# Altium schematic sheet Sheet1.SchDoc(Sheet1)
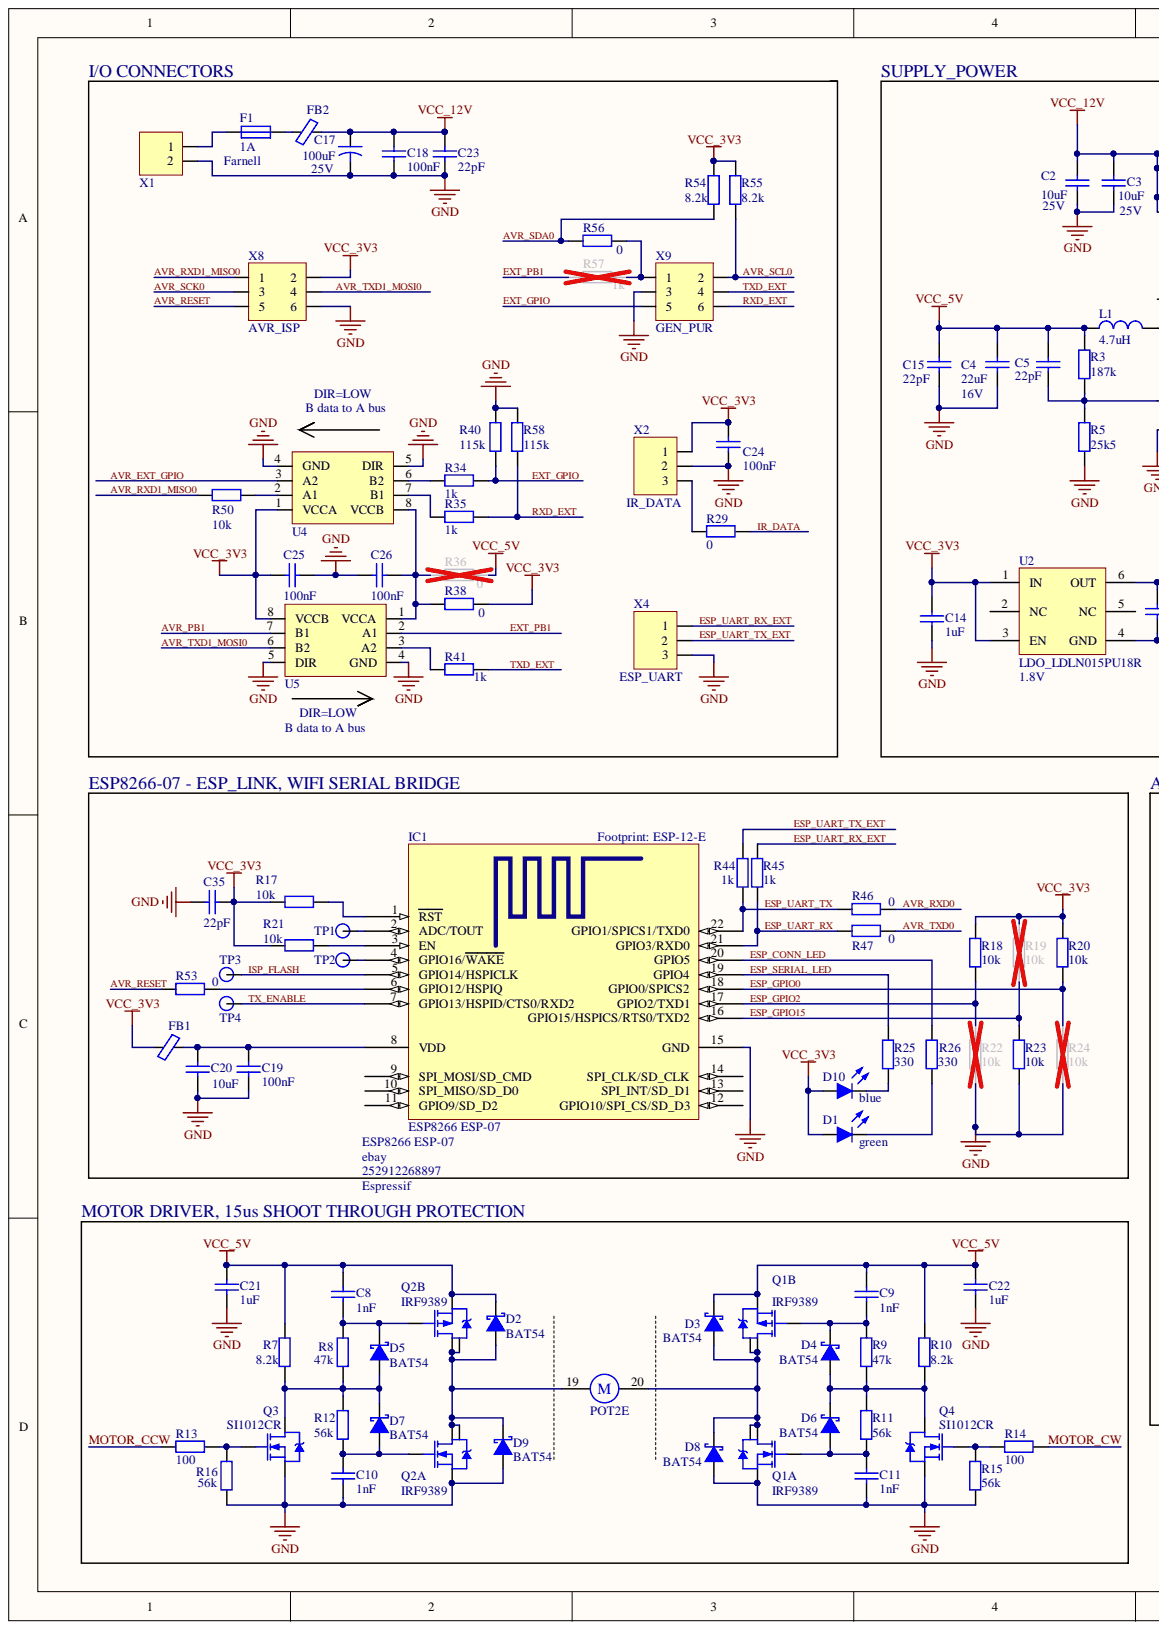
[diagram p0: Sheet1.SchDoc, left]
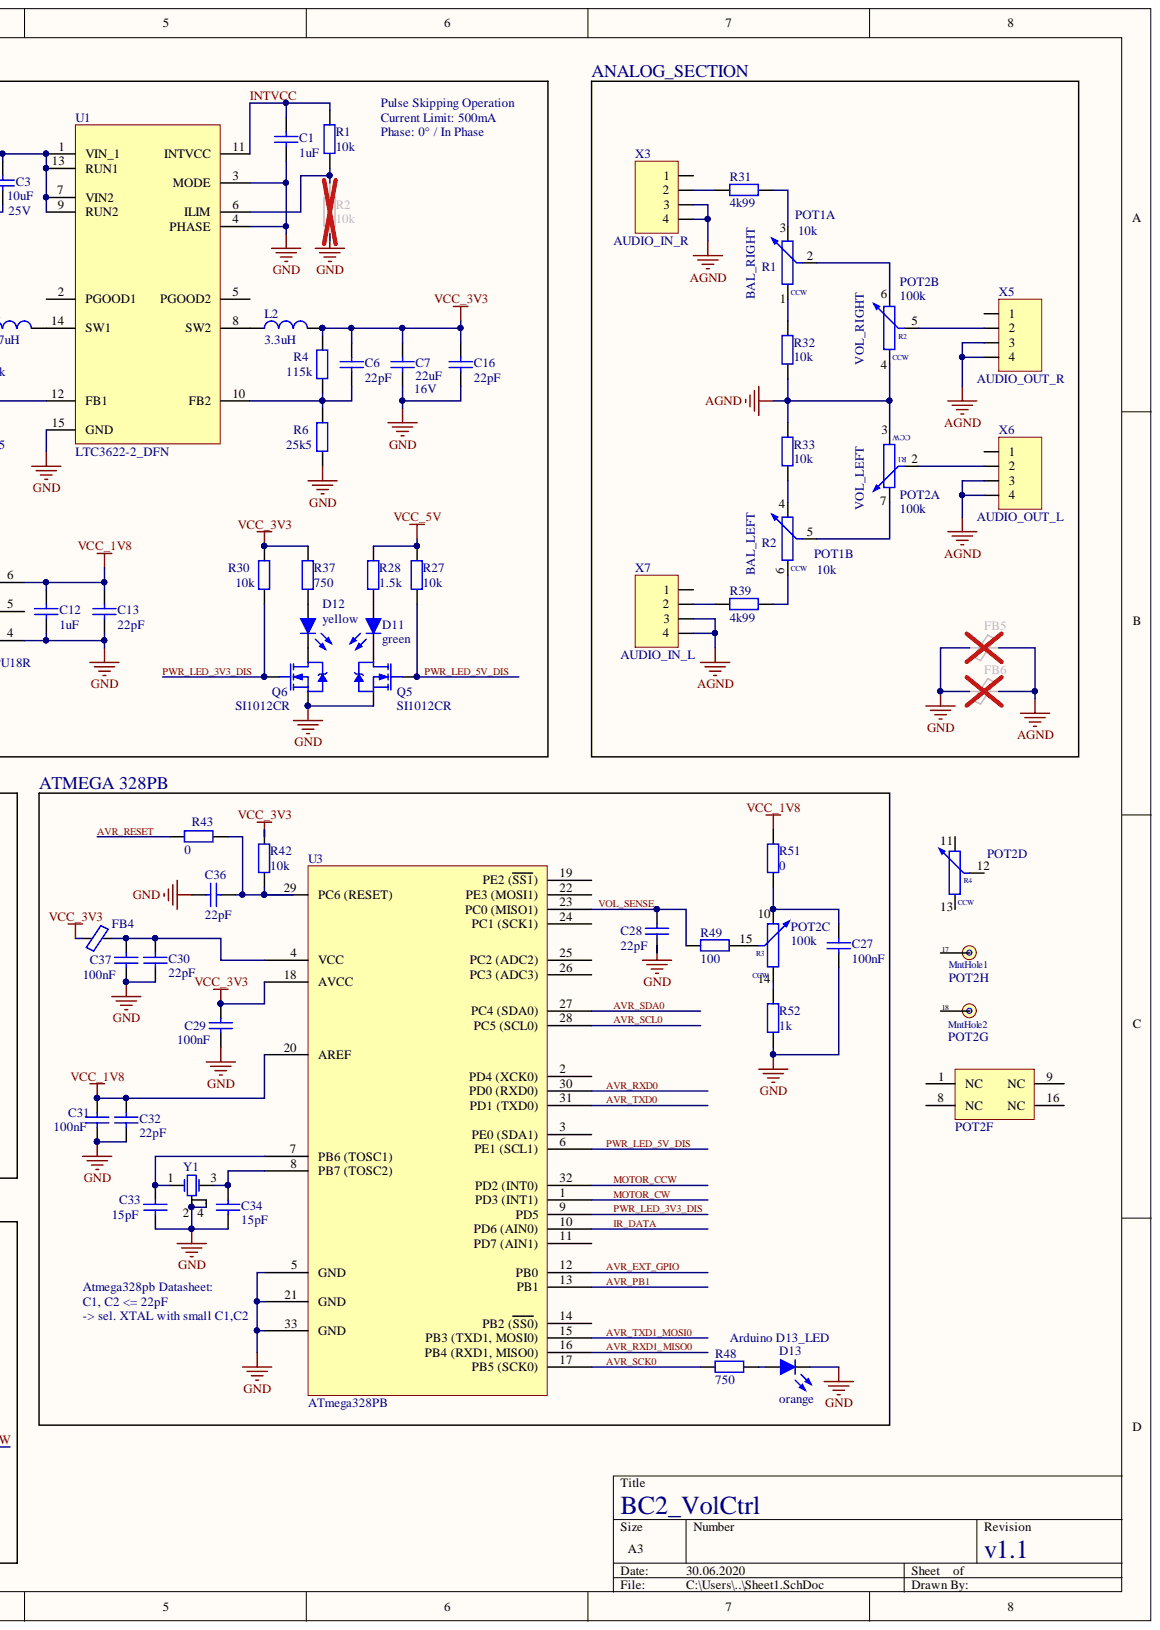
[diagram p1: Sheet1.SchDoc, right]

## Parts
C1  value 1uF  [CAPC1608N]
C2  value 10uF  Voltage: 25V  [CAPC3216N]
C3  value 10uF  Voltage: 25V  [CAPC3216N]
C4  value 22uF  Voltage: 16V  [CAPC2012N]
C5  value 22pF  [CAPC1608N]
C6  value 22pF  [CAPC1608N]
C7  value 22uF  Voltage: 16V  [CAPC2012N]
C8  value 1nF  [CAPC1608N]
C9  value 1nF  [CAPC1608N]
C10  value 1nF  [CAPC1608N]
C11  value 1nF  [CAPC1608N]
C12  value 1uF  [CAPC1608N]
C13  value 22pF  [CAPC1608N]
C14  value 1uF  [CAPC1608N]
C15  value 22pF  [CAPC1608N]
C16  value 22pF  [CAPC1608N]
C17  value 100uF  Voltage: 25V  [CRAD_6.3mm]  (hidden comment: FK Series)
C18  value 100nF  [CAPC1608N]
C19  value 100nF  [CAPC1608N]
C20  value 10uF  [CAPC3216N]  (hidden comment: C1206_10uF)
C21  value 1uF  [CAPC1608N]
C22  value 1uF  [CAPC1608N]
C23  value 22pF  [CAPC1608N]
C24  value 100nF  [CAPC1608N]
C25  value 100nF  [CAPC1608N]
C26  value 100nF  [CAPC1608N]
C27  value 100nF  [CAPC1608N]
C28  value 22pF  [CAPC1608N]
C29  value 100nF  [CAPC1608N]
C30  value 22pF  [CAPC1608N]
C31  value 100nF  [CAPC1608N]
C32  value 22pF  [CAPC1608N]
C33  value 15pF  [CAPC1608N]
C34  value 15pF  [CAPC1608N]
C35  value 22pF  [CAPC1608N]
C36  value 22pF  [CAPC1608N]
C37  value 100nF  [CAPC1608N]
D1  Color: green  [LED_0603]
D2  BAT54  [SOD323]
D3  BAT54  [SOD323]
D4  BAT54  [SOD323]
D5  BAT54  [SOD323]
D6  BAT54  [SOD323]
D7  BAT54  [SOD323]
D8  BAT54  [SOD323]
D9  BAT54  [SOD323]
D10  Color: blue  [LED_0603]
D11  Color: green  [LED_0603]
D12  Color: yellow  [LED_0603]
D13  Color: orange  [LED_0603]
F1  Supplier: Farnell  Current: 1A  [RESC1608N]  (hidden comment: Fuse_0603_1A)
FB1  FB_1.5k_1A  [0805_FERRITE]  (value not drawn)
FB2  FB_1.5k_1A  [0805_FERRITE]  (value not drawn)
FB4  FB_1.5k_1A  [0805_FERRITE]  (value not drawn)
FB5  FB_1.5k_1A  [0805_FERRITE]  (value not drawn)
FB6  FB_1.5k_1A  [0805_FERRITE]  (value not drawn)
IC1  ESP8266 ESP-07  Supplier: ebay  [ESP8266 ESP-12-E]
L1  value 4.7uH  [L_XFL4020]  (hidden comment: XFL4020)
L2  value 3.3uH  [L_XFL4020]  (hidden comment: XFL4020)
POT1  value 10k  [RK27112A]  (hidden comment: POT_RK27112A)  (parts A,B)
POT2  value 100k  [RK16814MGA0K]  (parts A,B,C,D,E,F,G,H)
Q1  IRF9389  [SO-8]  (parts A,B)
Q2  IRF9389  [SO-8]  (parts A,B)
Q3  SI1012CR  [SC-75A]
Q4  SI1012CR  [SC-75A]
Q5  SI1012CR  [SC-75A]
Q6  SI1012CR  [SC-75A]
R1  value 10k  [RESC1608N]
R2  value 10k  [RESC1608N]
R3  value 187k  [RESC1608N]
R4  value 115k  [RESC1608N]
R5  value 25k5  [RESC1608N]
R6  value 25k5  [RESC1608N]
R7  value 8.2k  [RESC1608N]
R8  value 47k  [RESC1608N]
R9  value 47k  [RESC1608N]
R10  value 8.2k  [RESC1608N]
R11  value 56k  [RESC1608N]
R12  value 56k  [RESC1608N]
R13  value 100  [RESC1608N]
R14  value 100  [RESC1608N]
R15  value 56k  [RESC1608N]
R16  value 56k  [RESC1608N]
R17  value 10k  [RESC1608N]
R18  value 10k  [RESC1608N]
R19  value 10k  [RESC1608N]
R20  value 10k  [RESC1608N]
R21  value 10k  [RESC1608N]
R22  value 10k  [RESC1608N]
R23  value 10k  [RESC1608N]
R24  value 10k  [RESC1608N]
R25  value 330  [RESC1608N]
R26  value 330  [RESC1608N]
R27  value 10k  [RESC1608N]
R28  value 1.5k  [RESC1608N]  (hidden comment: R0603_1.5k)
R29  value 0  [RESC1608N]
R30  value 10k  [RESC1608N]
R31  value 4k99  [RESC1608N]
R32  value 10k  [RESC1608N]
R33  value 10k  [RESC1608N]
R34  value 1k  [RESC1608N]
R35  value 1k  [RESC1608N]
R36  value 0  [RESC1608N]
R37  value 750  [RESC1608N]
R38  value 0  [RESC1608N]
R39  value 4k99  [RESC1608N]
R40  value 115k  [RESC1608N]
R41  value 1k  [RESC1608N]
R42  value 10k  [RESC1608N]
R43  value 0  [RESC1608N]
R44  value 1k  [RESC1608N]
R45  value 1k  [RESC1608N]
R46  value 0  [RESC1608N]
R47  value 0  [RESC1608N]
R48  value 750  [RESC1608N]
R49  value 100  [RESC1608N]
R50  value 10k  [RESC1608N]
R51  value 0  [RESC1608N]
R52  value 1k  [RESC1608N]  (hidden comment: R0603_1k)
R53  value 0  [RESC1608N]
R54  value 8.2k  [RESC1608N]  (hidden comment: R0603_8.2k)
R55  value 8.2k  [RESC1608N]  (hidden comment: R0603_8.2k)
R56  value 0  [RESC1608N]
R57  value 1k  [RESC1608N]
R58  value 115k  [RESC1608N]
TP1  (no value)  [TP_0.3mm]
TP2  (no value)  [TP_0.3mm]
TP3  (no value)  [TP_0.3mm]
TP4  (no value)  [TP_0.3mm]
U1  LTC3622-2_DFN  [DFN-14]
U2  LDO_LDLN015PU18R  Vout: 1.8V  [DFN6]
U3  ATmega328PB  [ATMEL_32M1-A_L]
U4  (no value)  [SSOP-8_DCT]
U5  (no value)  [SSOP-8_DCT]
X1  Con_2x1_2.54mm  [HDR2x1]  (value not drawn)
X2  IR_DATA  [HDR3x1]
X3  AUDIO_IN_R  [MOLEX_MINI_FIT_4PIN]
X4  ESP_UART  [HDR3x1]
X5  AUDIO_OUT_R  [MOLEX_MINI_FIT_4PIN]
X6  AUDIO_OUT_L  [MOLEX_MINI_FIT_4PIN]
X7  AUDIO_IN_L  [MOLEX_MINI_FIT_4PIN]
X8  AVR_ISP  [HDR2X3_1_15_Drill]
X9  GEN_PUR  [HDR2X3_1_15_Drill]
Y1  XTAL_8MHz  [XTAL_5x3.2mm]  (value not drawn)

## Nets
AGND: FB5.1 FB6.1 POT2.3 POT2.4 R32.1 R33.1 X3.3 X3.4 X5.3 X5.4 X6.3 X6.4 X7.3 X7.4
GND: C1.1 C2.2 C3.1 C4.1 C7.1 C10.1 C11.1 C12.1 C13.1 C14.1 C15.2 C16.1 C17.2 C18.1 C19.1 C20.1 C21.1 C22.1 C23.1 C24.1 C25.2 C26.2 C27.1 C28.1 C29.1 C30.1 C31.1 C32.1 C33.1 C34.1 C35.2 C36.2 C37.1 D8.1 D9.1 D13.2 FB5.2 FB6.2 IC1.15(GND) Q1.1 Q2.1 Q3.2 Q4.2 Q5.2 Q6.2 R2.1 R5.1 R6.1 R15.1 R16.1 R22.1 R23.1 R24.1 R40.1 R52.1 R58.1 U1.3(MODE) U1.4(PHASE) U1.15(GND) U2.4(GND) U3.5(GND) U3.21(GND) U3.33(GND) U4.4(GND) U4.5(DIR) U5.4(GND) U5.5(DIR) X1.2 X2.2 X4.3 X8.6 X9.3 Y1.2 Y1.4
VCC_1V8: C12.2 C13.2 C31.2 C32.2 R51.2 U2.6(OUT) U3.20(AREF)
VCC_3V3: C6.2 C7.2 C14.2 C16.2 C24.2 C25.1 C29.2 C35.1 D1.1 D10.1 FB1.2 FB4.1 L2.2 R4.2 R17.2 R18.2 R19.2 R20.2 R21.2 R30.2 R37.2 R38.2 R42.2 R54.2 R55.1 U2.1(IN) U2.3(EN) U3.18(AVCC) U4.1(VCCA) U5.8(VCCB) X2.1 X8.2
VCC_5V: C4.2 C5.2 C8.2 C9.2 C15.1 C21.2 C22.2 D2.2 D3.2 L1.1 Q1.3 Q2.3 R3.1 R7.1 R10.1 R27.2 R28.2 R36.2
VCC_12V: C2.1 C3.2 C17.1 C18.2 C23.2 FB2.1 U1.1(VIN_1) U1.7(VIN2) U1.9(RUN2) U1.13(RUN1)
AVR_EXT_GPIO: U3.12(PB0) U4.3(A2)
AVR_PB1: U3.13(PB1) U5.7(B1)
AVR_RESET: R43.1 R53.1 X8.5
AVR_RXD0: R46.2 U3.30(PD0_(RXD0))
AVR_RXD1_MISO0: R50.2 U3.16(PB4_(RXD1,_MISO0)) X8.1
AVR_SCK0: R48.1 U3.17(PB5_(SCK0)) X8.3
AVR_SCL0: R55.2 U3.28(PC5_(SCL0)) X9.2
AVR_SDA0: R54.1 R56.1 U3.27(PC4_(SDA0))
AVR_TXD0: R47.2 U3.31(PD1_(TXD0))
AVR_TXD1_MOSI0: U3.15(PB3_(TXD1,_MOSI0)) U5.6(B2) X8.4
ESP_CONN_LED: IC1.20(GPIO5) R26.2
ESP_GPIO0: IC1.18(GPIO0/SPICS2) R20.1 R24.2
ESP_GPIO2: IC1.17(GPIO2/TXD1) R18.1 R22.2
ESP_GPIO15: IC1.16(GPIO15/HSPICS/RTS0/TXD2) R19.1 R23.2
ESP_SERIAL_LED: IC1.19(GPIO4) R25.2
ESP_UART_RX: IC1.21(GPIO3/RXD0) R45.2 R47.1
ESP_UART_RX_EXT: R45.1 X4.1
ESP_UART_TX: IC1.22(GPIO1/SPICS1/TXD0) R44.2 R46.1
ESP_UART_TX_EXT: R44.1 X4.2
EXT_GPIO: R34.2 R40.2 X9.5
EXT_PB1: R57.2 U5.2(A1)
INTVCC: C1.2 R1.2 U1.11(INTVCC)
IR_DATA: R29.2 U3.10(PD6_(AIN0))
ISP_FLASH: IC1.5(GPIO14/HSPICLK) TP3.1
MOTOR_CCW: R13.1 U3.32(PD2_(INT0))
MOTOR_CW: R14.1 U3.1(PD3_(INT1))
PWR_LED_3V3_DIS: Q6.1 R30.1 U3.9(PD5)
PWR_LED_5V_DIS: Q5.1 R27.1 U3.6(PE1_(SCL1))
RXD_EXT: R35.1 R58.2 X9.6
TX_ENABLE: IC1.7(GPIO13/HSPID/CTS0/RXD2) TP4.1
TXD_EXT: R41.1 X9.4
VOL_SENSE: C28.2 R49.2 U3.23(PC0_(MISO1))
NetC5_1: C5.1 R3.2 R5.2 U1.12(FB1)
NetC6_1: C6.1 R4.1 R6.2 U1.10(FB2)
NetC8_1: C8.1 D5.2 Q2.4 R8.2
NetC9_1: C9.1 D4.2 Q1.4 R9.2
NetC10_2: C10.2 D7.1 Q2.2 R12.1
NetC11_2: C11.2 D6.1 Q1.2 R11.1
NetC19_2: C19.2 C20.2 FB1.1 IC1.8(VDD)
NetC26_1: C26.1 R36.1 R38.1 U4.8(VCCB) U5.1(VCCA)
NetC27_2: C27.2 POT2.10 R51.1
NetC30_2: C30.2 C37.2 FB4.2 U3.4(VCC)
NetC33_2: C33.2 U3.7(PB6_(TOSC1)) Y1.1
NetC34_2: C34.2 U3.8(PB7_(TOSC2)) Y1.3
NetC36_1: C36.1 R42.1 R43.2 U3.29(PC6_(RESET))
NetD1_2: D1.2 R26.1
NetD2_1: D2.1 D9.2 POT2.19 Q2.5 Q2.6 Q2.7 Q2.8
NetD3_1: D3.1 D8.2 POT2.20(M) Q1.5 Q1.6 Q1.7 Q1.8
NetD4_1: D4.1 D6.2 Q4.3 R9.1 R10.2 R11.2
NetD5_1: D5.1 D7.2 Q3.3 R7.2 R8.1 R12.2
NetD10_2: D10.2 R25.1
NetD11_1: D11.1 R28.1
NetD11_2: D11.2 Q5.3
NetD12_1: D12.1 R37.1
NetD12_2: D12.2 Q6.3
NetD13_1: D13.1 R48.2
NetF1_1: F1.1 X1.1
NetF1_2: F1.2 FB2.2
NetIC1_1: IC1.1(RST) R17.1
NetIC1_2: IC1.2(ADC/TOUT) TP1.1
NetIC1_3: IC1.3(EN) R21.1
NetIC1_4: IC1.4(GPIO16/WAKE) TP2.1
NetIC1_6: IC1.6(GPIO12/HSPIQ) R53.2
NetL1_2: L1.2 U1.14(SW1)
NetL2_1: L2.1 U1.8(SW2)
NetPOT1_1: POT1.1 R32.2
NetPOT1_2: POT1.2 POT2.6
NetPOT1_3: POT1.3 R31.2
NetPOT1_4: POT1.4 R33.2
NetPOT1_5: POT1.5 POT2.7
NetPOT1_6: POT1.6 R39.2
NetPOT2_2: POT2.2 X6.2
NetPOT2_5: POT2.5 X5.2
NetPOT2_14: POT2.14 R52.2
NetPOT2_15: POT2.15 R49.1
NetQ3_1: Q3.1 R13.2 R16.2
NetQ4_1: Q4.1 R14.2 R15.2
NetR1_1: R1.1 R2.2 U1.6(ILIM)
NetR29_1: R29.1 X2.3
NetR31_1: R31.1 X3.2
NetR34_1: R34.1 U4.6(B2)
NetR35_2: R35.2 U4.7(B1)
NetR39_1: R39.1 X7.2
NetR41_2: R41.2 U5.3(A2)
NetR50_1: R50.1 U4.2(A1)
NetR56_2: R56.2 R57.1 X9.1

Not connected: IC1 9(SPI_MOSI/SD_CMD) 10(SPI_MISO/SD_D0) 11(GPIO9/SD_D2) 12(GPIO10/SPI_CS/SD_D3) 13(SPI_INT/SD_D1) 14(SPI_CLK/SD_CLK); POT2 1(NC) 8(NC) 9(NC) 11 12 13 16(NC) 17 18; U1 2(PGOOD1) 5(PGOOD2); U2 2(NC) 5(NC); U3 2(PD4_(XCK0)) 3(PE0_(SDA1)) 11(PD7_(AIN1)) 14(PB2_(SS0)) 19(PE2_(SS1)) 22(PE3_(MOSI1)) 24(PC1_(SCK1)) 25(PC2_(ADC2)) 26(PC3_(ADC3)); X3 1; X5 1; X6 1; X7 1

## Library data of the parts
C1 C12 C14 C21 C22 = C0603_1uF: 25V,X5R; Part number: GCJ188R71E105KA01D; Manufacturer: Murata; Order number: 2781410; Dielectric: X7R; Voltage: 25V; Supplier: Farnell; Component Kind: Standard; Library Name: Schlib_holzi.SchLib
C2 C3 C20 = C1206_10uF: 25V,X7R; Part number: CGA5L1X7R1E106K160AC; Manufacturer: TDK; Order number: 2211068; Supplier: Farnell; Dielectric: X7R; Component Kind: Standard; Library Name: Schlib_holzi.SchLib
  C20: Voltage: 25V
C4 C7 = C0805_22uF: 10V,X5R; Part number: GRM21BR61C226ME44L; Manufacturer: Murata; Order number: 2688534; Supplier: Farnell; Dielectric: X5R; Component Kind: Standard; Library Name: Schlib_holzi.SchLib
C5 C6 C13 C15 C16 C23 C28 C30 C32 C35 C36 = C0603_22pF: 50V,C0G; Part number: GCM1885C1H220JA16D; Manufacturer: Murata; Order number: 2494189; Voltage: 50V; Supplier: Farnell; Dielectric: C0G; Component Kind: Standard; Library Name: Schlib_holzi.SchLib
C8-C11 = C0603_1nF: 100V,X7R; Part number: 06031C102JAT2A; Manufacturer: AVX; Order number: 1740627; Voltage: 100V; Supplier: Farnell; Dielectric: X7R; Component Kind: Standard; Library Name: Schlib_holzi.SchLib
C17 = CRAD_6.3mm_100uF: Electrolytic, 25V; Part number: EEEFK1E101XP; Manufacturer: Panasonic; Order number: 1850109; Supplier: Farnell; Series: FK; Dielectric: Electrolytic; Component Kind: Standard; Library Name: Schlib_holzi.SchLib
C18 C19 C24-C27 C29 C31 C37 = C0603_100nF: 50V,X7R; Part number: GCJ188R71H104KA12D; Manufacturer: Murata; Order number: 2688469; Voltage: 50V; Supplier: Farnell; Dielectric: X7R; Component Kind: Standard; Library Name: Schlib_holzi.SchLib
C33 C34 = C0603_15pF: 50V,C0G; Part number: C1608C0G1H150J080AA; Manufacturer: TDK; Order number: 1907286; Voltage: 50V; Supplier: Farnell; Dielectric: C0G; Component Kind: Standard; Library Name: Schlib_holzi.SchLib
D1 D11 = LED0603_green: green, 2mA, 2.65V, 0603; Part number: KPTD-1608LVZGCK; Manufacturer: Kingbright; Order number: 2610414; Voltage: 2.65V; Supplier: Farnell; Current: 2mA; Component Kind: Standard; Library Name: Schlib_holzi.SchLib
D2-D9 = diode_schottky_BAT54_SOD323: SOD323,2PIN,BAT54JFILM,; Manufacturer: Vishay; Voltage: 40V; Order number: 9801502; Current: 0.3A; Capacitance: 7pF; Supplier: Farnell; Part number: BAT54JFILM; Component Kind: Standard; Library Name: Schlib_holzi.SchLib
D10 = LED0603_blue: blue, 2mA, 2.65V, 0603; Part number: KPTD-1608LVVBC-D; Manufacturer: Kingbright; Order number: 2610415; Voltage: 2.65V; Supplier: Farnell; Current: 2mA; Component Kind: Standard; Library Name: Schlib_holzi.SchLib
D12 = LED0603_yellow: yellow, 2mA, 1.85V, 0603; Part number: KPTD-1608LVSYCK-J3-PF; Manufacturer: Kingbright; Order number: 2610413; Voltage: 1.85V; Supplier: Farnell; Current: 2mA; Component Kind: Standard; Library Name: Schlib_holzi.SchLib
D13 = LED0603_orange: orange, 2mA, 1.8V, 0603; Part number: KPTD-1608LVSECK-J4-PF; Manufacturer: Kingbright; Order number: 2610412; Voltage: 1.8V; Supplier: Farnell; Current: 2mA; Component Kind: Standard; Library Name: Schlib_holzi.SchLib
F1 = Fuse0603_1A: Fuse; Part Number: SF-0603F100-2; Manufacturer: Bourns; Order number: 1652131; Characteristic: fast acting; Component Kind: Standard; Library Name: Schlib_holzi.SchLib
FB1 FB2 FB4-FB6 = FB_1.5k_1A: Z=1.5k@100MHz, 1A, R=300mOhm; Part number: 742792097; Manufacturer: WURTH ELEKTRONIK; Resistance DC: 300m; Order number: 1635738; Impedance_100MHz: 1.5k; Supplier: Farnell; Current: 1A; Component Kind: Standard; Library Name: Schlib_holzi.SchLib
IC1 = ESP8266 ESP-12-E: Manufacturer: Espressif; Order number: 252912268897; Part number: ESP8266 ESP-07; Library Name: ESP8266.SchLib; Description: ESP8266 ESP-12-E; Component Kind: Standard
L1 = L_XFL4020_4.7uH: Shielded Power Inductor; Part number: XFL4020-472MEC; Manufacturer: Coilcraft; Order number: 2289218; Isat: 2A; Imax_rms: 5A; Supplier: Farnell; Component Kind: Standard; Library Name: Schlib_holzi.SchLib
L2 = L_XFL4020_3.3uH: Shielded Power Inductor; Part number: XFL4020-332MEB; Manufacturer: Coilcraft; Order number: 2289217; Isat: 2.7A; Imax_rms: 5.2A; Supplier: Farnell; Component Kind: Standard; Library Name: Schlib_holzi.SchLib
POT1 = POT_RK27112A: 10k, lin, dual; Part number: RK27112A0-S-25-C0-V103; Manufacturer: ALPS; Resistance Taper: lin (3B); Order number: 362309570555; Supplier: ebay; Component Kind: Standard; Library Name: Schlib_holzi.SchLib
POT2 = POT_RK16814MGA0K: 100k, log, quad; Manufacturer: ALPS; Resistance Taper: log (15A); Order number: 1548223; Supplier: Rsonline; Part number: RK16814MGA0K; Component Kind: Standard; Library Name: Schlib_holzi.SchLib
Q1 Q2 = nmos_pmpos_mult_IRF9389: nmos; Part number: IRF9389TRPBF; Manufacturer: Infineon; Vds: 30V; Order number: 2579952; Current: 6.8;-4.6A; Supplier: Farnell; Component Kind: Standard; Library Name: Schlib_holzi.SchLib
Q3-Q6 = nmos_SI1012CR: nmos; Part number: SI1012CR-T1-GE3; Manufacturer: Vishay; Vds: 20V; Order number: 2056674; Current: 500mA@Vgs=2.5V; Supplier: Farnell; Component Kind: Standard; Library Name: Schlib_holzi.SchLib
R1 R2 R17-R24 R27 R30 R32 R33 R42 R50 = R0603_10k: 250mW,75V; Part number: CRCW060310K0FKEAHP; Manufacturer: Vishay; Tolerance: 1%; Order number: 1738918; Voltage: 75V; Supplier: Farnell; Power rating: 250mW; Component Kind: Standard; Library Name: Schlib_holzi.SchLib
R3 = R0603_187k: 100mW,75V; Part number: ERJ3EKF1873V; Manufacturer: Panasonic; Tolerance: 1%; Order number: 2303248; Voltage: 75V; Supplier: Farnell; Power rating: 100mW; Component Kind: Standard; Library Name: Schlib_holzi.SchLib
R4 R40 R58 = R0603_115k: 100mW,75V; Part number: CRCW0603115KFKEA; Manufacturer: Vishay; Tolerance: 1%; Order number: 2138503; Voltage: 75V; Supplier: Farnell; Power rating: 100mW; Component Kind: Standard; Library Name: Schlib_holzi.SchLib
R5 R6 = R0603_25k5: 100mW,75V; Part number: CRCW060325K5FKEA; Manufacturer: Vishay; Tolerance: 1%; Order number: 2138447; Voltage: 75V; Supplier: Farnell; Power rating: 100mW; Component Kind: Standard; Library Name: Schlib_holzi.SchLib
R7 R10 R54 R55 = R0603_8.2k: 250mW,75V; Part number: CRCW06038K20FKEAHP; Manufacturer: Vishay; Tolerance: 1%; Order number: 1738917; Voltage: 75V; Supplier: Farnell; Power rating: 250mW; Component Kind: Standard; Library Name: Schlib_holzi.SchLib
R8 R9 = R0603_47k: 250mW,75V; Part number: CRCW060347K0FKEAHP; Manufacturer: Vishay; Tolerance: 1%; Order number: 1738927; Voltage: 75V; Supplier: Farnell; Power rating: 250mW; Component Kind: Standard; Library Name: Schlib_holzi.SchLib
R11 R12 R15 R16 = R0603_56k: 250mW,75V; Part number: CRCW060356K0FKEAHP; Manufacturer: Vishay; Tolerance: 1%; Order number: 1738928; Voltage: 75V; Supplier: Farnell; Power rating: 250mW; Component Kind: Standard; Library Name: Schlib_holzi.SchLib
R13 R14 R49 = R0603_100: 250mW,75V; Part number: CRCW0603100RFKEAHP; Manufacturer: Vishay; Tolerance: 1%; Order number: 1738891; Voltage: 75V; Supplier: Farnell; Power rating: 250mW; Component Kind: Standard; Library Name: Schlib_holzi.SchLib
R25 R26 = R0603_330: 250mW,75V; Part number: CRCW0603330RFKEAHP; Manufacturer: Vishay; Tolerance: 1%; Order number: 1738898; Voltage: 75V; Supplier: Farnell; Power rating: 250mW; Component Kind: Standard; Library Name: Schlib_holzi.SchLib
R28 = R0603_1.5k: 100mW,75V; Part number: CRCW06031K50FKEA; Manufacturer: Vishay; Tolerance: 1%; Order number: 1469743; Voltage: 75V; Supplier: Farnell; Power rating: 100mW; Component Kind: Standard; Library Name: Schlib_holzi.SchLib
R29 R36 R38 R43 R46 R47 R51 R53 R56 = R0603_0: 100mW,75V; Part number: CRCW06030000Z0EA; Manufacturer: Vishay; Order number: 1469739; Voltage: 75V; Tolerance: -; Supplier: Farnell; Power rating: 100mW; Component Kind: Standard; Library Name: Schlib_holzi.SchLib
R31 R39 = R0603_4.99k: 100mW,75V; Part number: CRCW06034K99FKEA; Manufacturer: Vishay; Tolerance: 1%; Order number: 1652885; Voltage: 75V; Supplier: Farnell; Power rating: 100mW; Component Kind: Standard; Library Name: Schlib_holzi.SchLib
R34 R35 R41 R44 R45 R52 R57 = R0603_1k: 100mW,75V; Part number: CRCW06031K00FKEA; Manufacturer: Vishay; Tolerance: 1%; Order number: 1469740; Voltage: 75V; Supplier: Farnell; Power rating: 100mW; Component Kind: Standard; Library Name: Schlib_holzi.SchLib
R37 R48 = R0603_750: 100mW,75V; Part number: CRCW0603750RFKEA; Manufacturer: Vishay; Tolerance: 1%; Order number: 1652900; Voltage: 75V; Supplier: Farnell; Power rating: 100mW; Component Kind: Standard; Library Name: Schlib_holzi.SchLib
TP1-TP4 = TP: Testpoint; Part number: NA; Supplier: NA; Order number: NA; Manufacturer: NA; Component Kind: Standard; Library Name: Schlib_holzi.SchLib
U1 = LTC3622-2_DFN: Buck, 2 Channel, 2.25MHz; Part number: LTC3622HDE-2#PBF; Manufacturer: Linear; Vin_max: 17V; Order number: 2483300; Switching Freq: 2.25MHz; Supplier: Farnell; Component Kind: Standard; Library Name: Schlib_holzi.SchLib
U2 = LDO_LDLN015PU18R: Low dropout regulator; Manufacturer: STMICRO; Vin_max: 5.5V; Order number: 2460822; Supplier: Farnell; Part number: LDLN015PU18R; Component Kind: Standard; Library Name: Schlib_holzi.SchLib
U3 = ATmega328PB: 8Bit MCU; Part number: ATMEGA328PB-MN; Manufacturer: Microchip; Order number: 2920865; Temperature range: -40 to 105°C; Supplier: Farnell; Component Kind: Standard; Library Name: Schlib_holzi.SchLib
U4 U5 = IC_LVL_TRNS_SN74LVC2T45DCTR: Bus-Transceiver; Manufacturer: Texas Instruments; Order number: 2854638; Supplier: Farnell; Part number: SN74LVC2T45DCTR; Component Kind: Standard; Library Name: Schlib_holzi.SchLib
X1 = Con_2x1_2.54mm: 2-Pin, Header, 2.54mm; Part number: TSW-102-07-G-S; Manufacturer: SAMTEC; Order number: 2505044; Supplier: Farnell; Series: TSW; Pin count: 2; Component Kind: Standard; Library Name: Schlib_holzi.SchLib
X2 X4 = Con_3x1_2.54mm: 3-Pin, Header, 2.54mm; Manufacturer: MOLEX; Order number: 2751450; Supplier: Farnell; Series: KK 254 42375; Pin count: 3; Part number: 22-28-4032; Component Kind: Standard; Library Name: Schlib_holzi.SchLib
X3 X5-X7 = Con_4Pin_Molex_Micro_Fit: Header, 4-Pin; Manufacturer: MOLEX; Order number: 9732098; Supplier: Farnell; Series: Mini-Fit; Pin count: 4; Part number: 39-28-1043; Component Kind: Standard; Library Name: Schlib_holzi.SchLib
X8 X9 = Con_3X2_2.54mm: 6-Pin, Header, 3x2, Dual row; Part number: 61300621121; Manufacturer: WURTH ELEKTRONIK; Pitch: 2.54mm; Order number: 2356131; Supplier: Farnell; Signal Integrity: Connector; Pin count: 6; Component Kind: Standard; Library Name: Schlib_holzi.SchLib
Y1 = XTAL_8MHz: 8MHz, 10ppm, 10pF; Part number: ABM3B-8.000MHZ-10-1-U-T; Manufacturer: ABRACON; Order number: 2467817; Supplier: Farnell; Frequency tolerance: 10ppm; Frequency: 8MHz; Component Kind: Standard; Cload: 10pF; Library Name: Schlib_holzi.SchLib
